# Altium schematic sheet Mainboard.SchDoc(Mainboard)
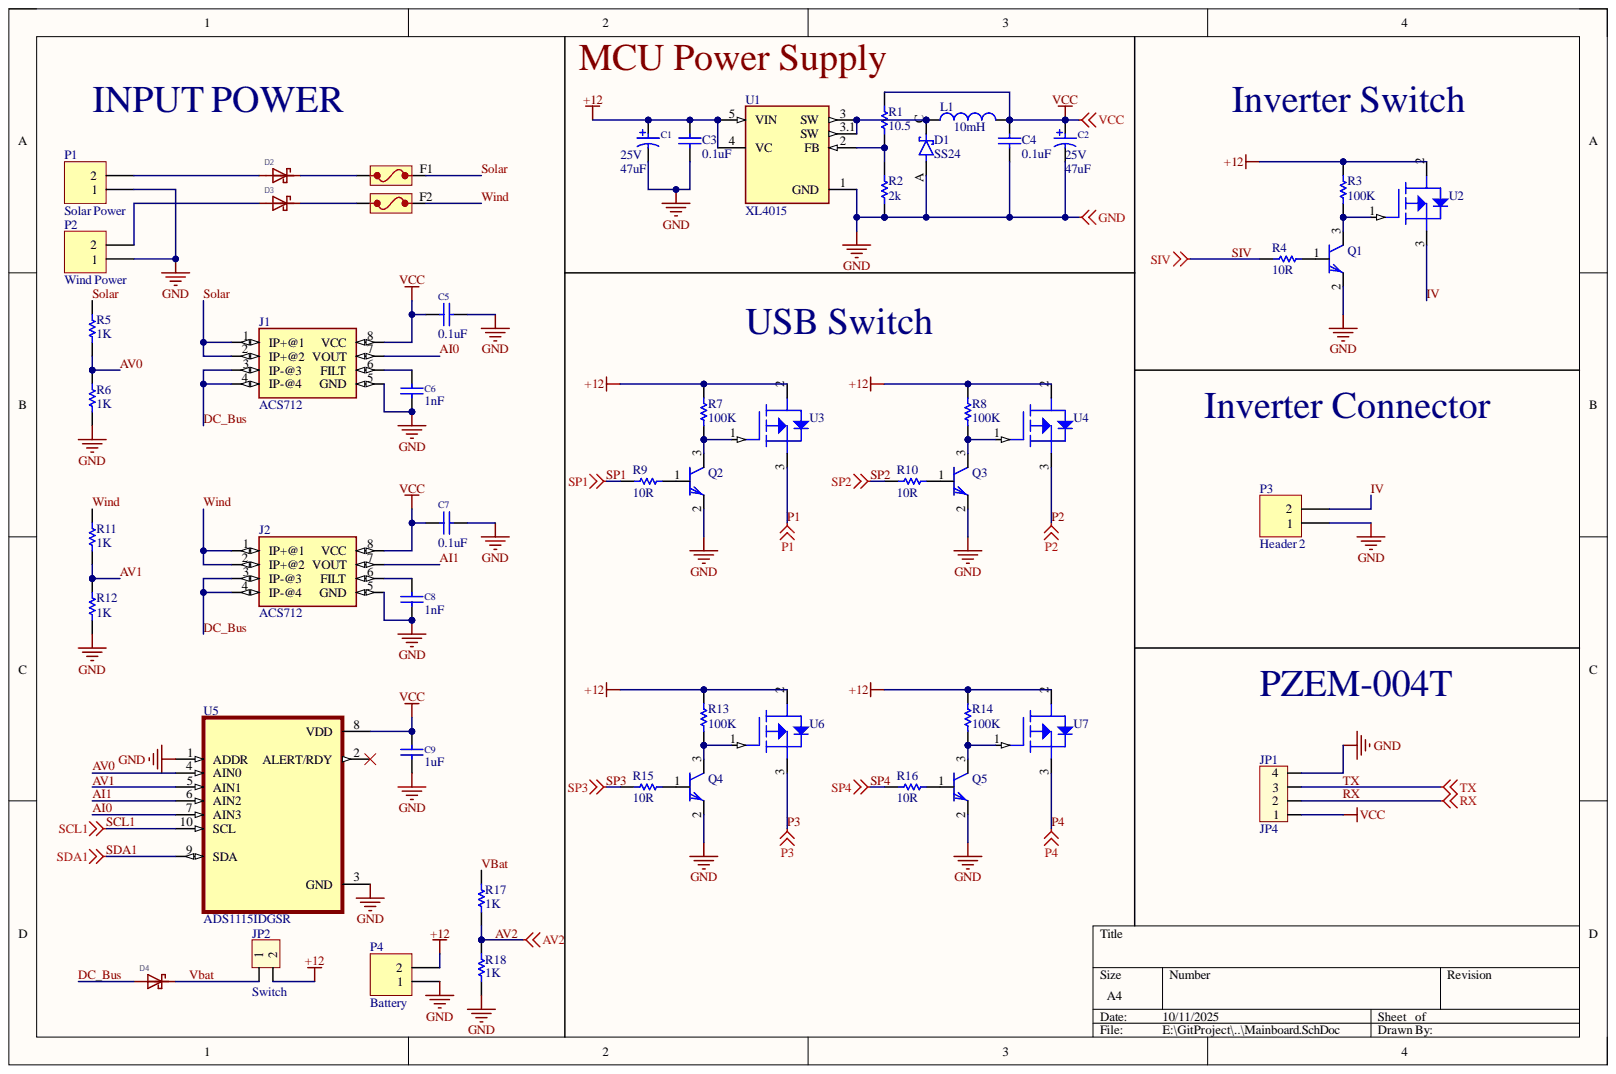
[diagram p0: Mainboard.SchDoc]

## Parts
C1  47uF  Volt: 25V  [CAP_SMD_6_3MM]
C2  47uF  Volt: 25V  [CAP_SMD_6_3MM]
C3  value 0.1uF  [C-NP-0805]  (hidden comment: Cap Non-pol)
C4  value 0.1uF  [C-NP-0805]  (hidden comment: Cap Non-pol)
C5  0.1uF  [C1608-0603]
C6  1nF  [C1608-0603]
C7  0.1uF  [C1608-0603]
C8  1nF  [C1608-0603]
C9  1uF  [C1608-0603]
D1  SS24  [DIOM5336X265N]
D2  (no value)
D3  (no value)
D4  (no value)
F1  FUSE  [FUSE 24.5x9.5]  (value not drawn)
F2  FUSE  [FUSE 24.5x9.5]  (value not drawn)
J1  ACS712  [SO08]
J2  ACS712  [SO08]
JP1  JP4  [CON4]
JP2  Switch  [CON2]
L1  value 10mH  [INDUCTOR 12.5x12.5]  (hidden comment: Inductor)
P1  Solar Power  [PTBlock 2]
P2  Wind Power  [PTBlock 2]
P3  Header 2  [PTBlock 2]
P4  Battery  [PTBlock 2]
Q1  C1815  [SOT23 [C1815]]  (value not drawn)
Q2  C1815  [SOT23 [C1815]]  (value not drawn)
Q3  C1815  [SOT23 [C1815]]  (value not drawn)
Q4  C1815  [SOT23 [C1815]]  (value not drawn)
Q5  C1815  [SOT23 [C1815]]  (value not drawn)
R1  value 10.5  [R-0805]  (hidden comment: RESISTOR)
R2  value 2k  [R-0805]  (hidden comment: RESISTOR)
R3  value 100K  [R-0805]  (hidden comment: RESISTOR)
R4  value 10R  [R-0805]  (hidden comment: RESISTOR)
R5  value 1K  [R-0805]  (hidden comment: RESISTOR)
R6  value 1K  [R-0805]  (hidden comment: RESISTOR)
R7  value 100K  [R-0805]  (hidden comment: RESISTOR)
R8  value 100K  [R-0805]  (hidden comment: RESISTOR)
R9  value 10R  [R-0805]  (hidden comment: RESISTOR)
R10  value 10R  [R-0805]  (hidden comment: RESISTOR)
R11  value 1K  [R-0805]  (hidden comment: RESISTOR)
R12  value 1K  [R-0805]  (hidden comment: RESISTOR)
R13  value 100K  [R-0805]  (hidden comment: RESISTOR)
R14  value 100K  [R-0805]  (hidden comment: RESISTOR)
R15  value 10R  [R-0805]  (hidden comment: RESISTOR)
R16  value 10R  [R-0805]  (hidden comment: RESISTOR)
R17  value 1K  [R-0805]  (hidden comment: RESISTOR)
R18  value 1K  [R-0805]  (hidden comment: RESISTOR)
U1  XL4015  [CONV_XL4015]
U2  IRF9540  [TO-263]  (value not drawn)
U3  IRF9540  [TO-263]  (value not drawn)
U4  IRF9540  [TO-263]  (value not drawn)
U5  ADS1115IDGSR  [SOP50P490X110-10N]
U6  IRF9540  [TO-263]  (value not drawn)
U7  IRF9540  [TO-263]  (value not drawn)

## Nets
+12: C1.1 C3.2 JP2.2 P4.2 R3.2 R7.2 R8.2 R13.2 R14.2 U1.4(VC) U1.5(VIN) U2.2 U3.2 U4.2 U6.2 U7.2
GND: C1.2 C2.2 C3.1 C4.1 C5.1 C6.1 C7.1 C8.1 C9.2 D1.A J1.5(GND) J2.5(GND) JP1.4 P1.1 P2.1 P3.1 P4.1 Q1.2 Q2.2 Q3.2 Q4.2 Q5.2 R2.1 R6.1 R12.1 R18.1 U1.1(GND) U5.1(ADDR) U5.3(GND)
VCC: C2.1 C4.2 C5.2 C7.2 C9.1 J1.8(VCC) J2.8(VCC) JP1.1 L1.2 R1.2 U5.8(VDD)
AI0: J1.7(VOUT) U5.7(AIN3)
AI1: J2.7(VOUT) U5.6(AIN2)
AV0: R5.1 R6.2 U5.4(AIN0)
AV1: R11.1 R12.2 U5.5(AIN1)
AV2: R17.1 R18.2
DC_Bus: D4.A J1.3(IP-@3) J1.4(IP-@4) J2.3(IP-@3) J2.4(IP-@4)
IV: P3.2 U2.3
P1: U3.3
P2: U4.3
P3: U6.3
P4: U7.3
RX: JP1.2
SCL1: U5.10(SCL)
SDA1: U5.9(SDA)
SIV: R4.2
Solar: F1.2 J1.1(IP+@1) J1.2(IP+@2) R5.2
SP1: R9.2
SP2: R10.2
SP3: R15.2
SP4: R16.2
TX: JP1.3
Vbat: D4.K JP2.1 R17.2
Wind: F2.2 J2.1(IP+@1) J2.2(IP+@2) R11.2
NetC6_2: C6.2 J1.6(FILT)
NetC8_2: C8.2 J2.6(FILT)
NetD1_C: D1.C L1.1 U1.3(SW) U1.3.1(SW)
NetD2_A: D2.A P1.2
NetD2_K: D2.K F1.1
NetD3_A: D3.A P2.2
NetD3_K: D3.K F2.1
NetQ1_1: Q1.1 R4.1
NetQ1_3: Q1.3 R3.1 U2.1
NetQ2_1: Q2.1 R9.1
NetQ2_3: Q2.3 R7.1 U3.1
NetQ3_1: Q3.1 R10.1
NetQ3_3: Q3.3 R8.1 U4.1
NetQ4_1: Q4.1 R15.1
NetQ4_3: Q4.3 R13.1 U6.1
NetQ5_1: Q5.1 R16.1
NetQ5_3: Q5.3 R14.1 U7.1
NetR1_1: R1.1 R2.2 U1.2(FB)
MCU Power Supply:

Not connected: U5 2(ALERT/RDY)

## Library data of the parts
C1 C2 = Cap_Pol: Polarized Capacitor; Manufacturer: FARNELL; AEMS Code: 2100110; Vendor: Digikey; Component Kind: Standard; ComponentLink1Description: Digikey Part Link; ComponentLink2Description: Open Datasheet; Published: 13-April-2009; Value: 47uF; ComponentLink2URL: H:\LIBRARY\LIBRARY.Datasheet\; Library Name: Kiet.IntLib; Publisher: Hoang Nguyen
C3 C4 = Cap Non-pol: Non-polarized Capacitor; Component Kind: Standard; LatestRevisionDate: 17-Jul-2002; LatestRevisionNote: Re-released for DXP Platform.; PackageReference: RAD-0.3; Published: 8-Jun-2000; Library Name: Kiet.IntLib; Publisher: Altium Limited
C5-C9 = Capacitor: AEMS Code: 2100341; Vendor: Digikey; Volt: 16V; Component Kind: Standard; ComponentLink1Description: Digikey Part Link; ComponentLink2Description: Open Datasheet; Description: Capacitor; PCB3D: SMT_0603; Published: 13-April-2009; Signal Integrity: Guessed Model; ComponentLink2URL: H:\LIBRARY\LIBRARY.Datasheet\; Library Name: Kiet.IntLib; Publisher: Hoang Nguyen
  C5 C7: Value: 0.1uF
  C6 C8: Value: 1nF
  C9: Value: 1uF
D1 = SS24: Diode Schottky 40V 2A Surface Mount DO-214AA (SMB); MF: ON Semiconductor; Availability: In Stock; Check_prices: https://www.snapeda.com/parts/SS24/Onsemi/view-part/?ref=eda; Component Kind: Standard; Library Name: SS24.IntLib; MP: SS24; Package: DO-214AA ON Semiconductor; Price: None; SnapEDA_Link: https://www.snapeda.com/parts/SS24/Onsemi/view-part/?ref=snap
D2-D4 = Schottky-Diode: ki_description: Schottky Diode; Component Kind: Standard; Datasheet: https://diotec.com/de/produktliste/SBD.html; ki_keywords: Schottky; Library Name: P1000A.IntLib
F1 F2 = FUSE_1: Vendor: Digikey; Component Kind: Standard; ComponentLink1Description: Digikey Part Link; ComponentLink2Description: Open Datasheet; Description: FUSE; Published: 13-April-2009; ComponentLink2URL: H:\LIBRARY\LIBRARY.Datasheet\; Library Name: Kiet.IntLib; Publisher: Hoang Nguyen
J1 J2 = ACS712: Current Sensor 20A 1 Channel Hall Effect, Open Loop Bidirectional 8-SOIC (0.154, 3.90mm Width); MF: Allegro MicroSystems; Check_prices: https://www.snapeda.com/parts/ACS712/Allegro+MicroSystems+LLC/view-part/?ref=eda; Component Kind: Standard; Library Name: ACS712.IntLib; MP: ACS712; Package: PowerWFQFN-12 Allegro MicroSystems LLC; SnapEDA_Link: https://www.snapeda.com/parts/ACS712/Allegro+MicroSystems+LLC/view-part/?ref=snap
JP1 = Con4: Connector 4; Component Kind: Standard; Value: Connector 4; Library Name: Kiet.IntLib
JP2 = Con2: Connector 2; Component Kind: Standard; Value: Connector 2; Library Name: Kiet.IntLib
P1-P4 = Header 2: Header, 2-Pin; Component Kind: Standard; LatestRevisionDate: 17-Jul-2002; LatestRevisionNote: Re-released for DXP Platform.; Library Name: Kiet.IntLib; Publisher: Altium Limited
Q1-Q5 = C1815: Q2SC1815; PSpiceTemplate: Q^@REFDES %c %b %e @MODEL; Vendor: Digikey; COMPONENT: 2SC1815; Component Kind: Standard; ComponentLink1Description: Digikey Part Link; ComponentLink2Description: Open Datasheet; Price: 500VND; Published: 13-April-2009; Simulation: Q2SC1815; ComponentLink2URL: H:\LIBRARY\LIBRARY.Datasheet\; Library Name: Kiet.IntLib; Publisher: Hoang Nguyen
U1 = XL4015: 5A 180KHz 36V Buck DC to DC Converter; Availability: Unavailable; Component Kind: Standard; Library Name: XL4015.IntLib; MP: XL4015; Package: None; Price: None
U2-U4 U6 U7 = IRF9540: IRF9540 - P-CHANNEL POWER MOSFETS; Vendor: Digikey; Component Kind: Standard; ComponentLink1Description: Digikey Part Link; ComponentLink2Description: Open Datasheet; Price: 7.000 VND; Published: 13-April-2009; ComponentLink2URL: H:\LIBRARY\LIBRARY.Datasheet\IRF9540.pdf; Library Name: Kiet.IntLib; Publisher: Hoang Nguyen
U5 = ADS1115IDGSR: MP: altium_native_batch; Availability: Unavailable; Component Kind: Standard; Library Name: ADS1115IDGSR.IntLib; Package: None; Price: None
L1 = Inductor: Component Kind: Standard; Description: Inductor; Library Name: thuvienhoang.IntLib
R1-R18 = RESISTOR: Component Kind: Standard; Description: Resistor; LatestRevisionDate: 17-Jul-2002; LatestRevisionNote: Re-released for DXP Platform.; PackageReference: AXIAL-0.3; Published: 8-Jun-2000; Library Name: Kiet.IntLib; Publisher: Altium Limited
